# Altium schematic sheet AUPSC.SchDoc
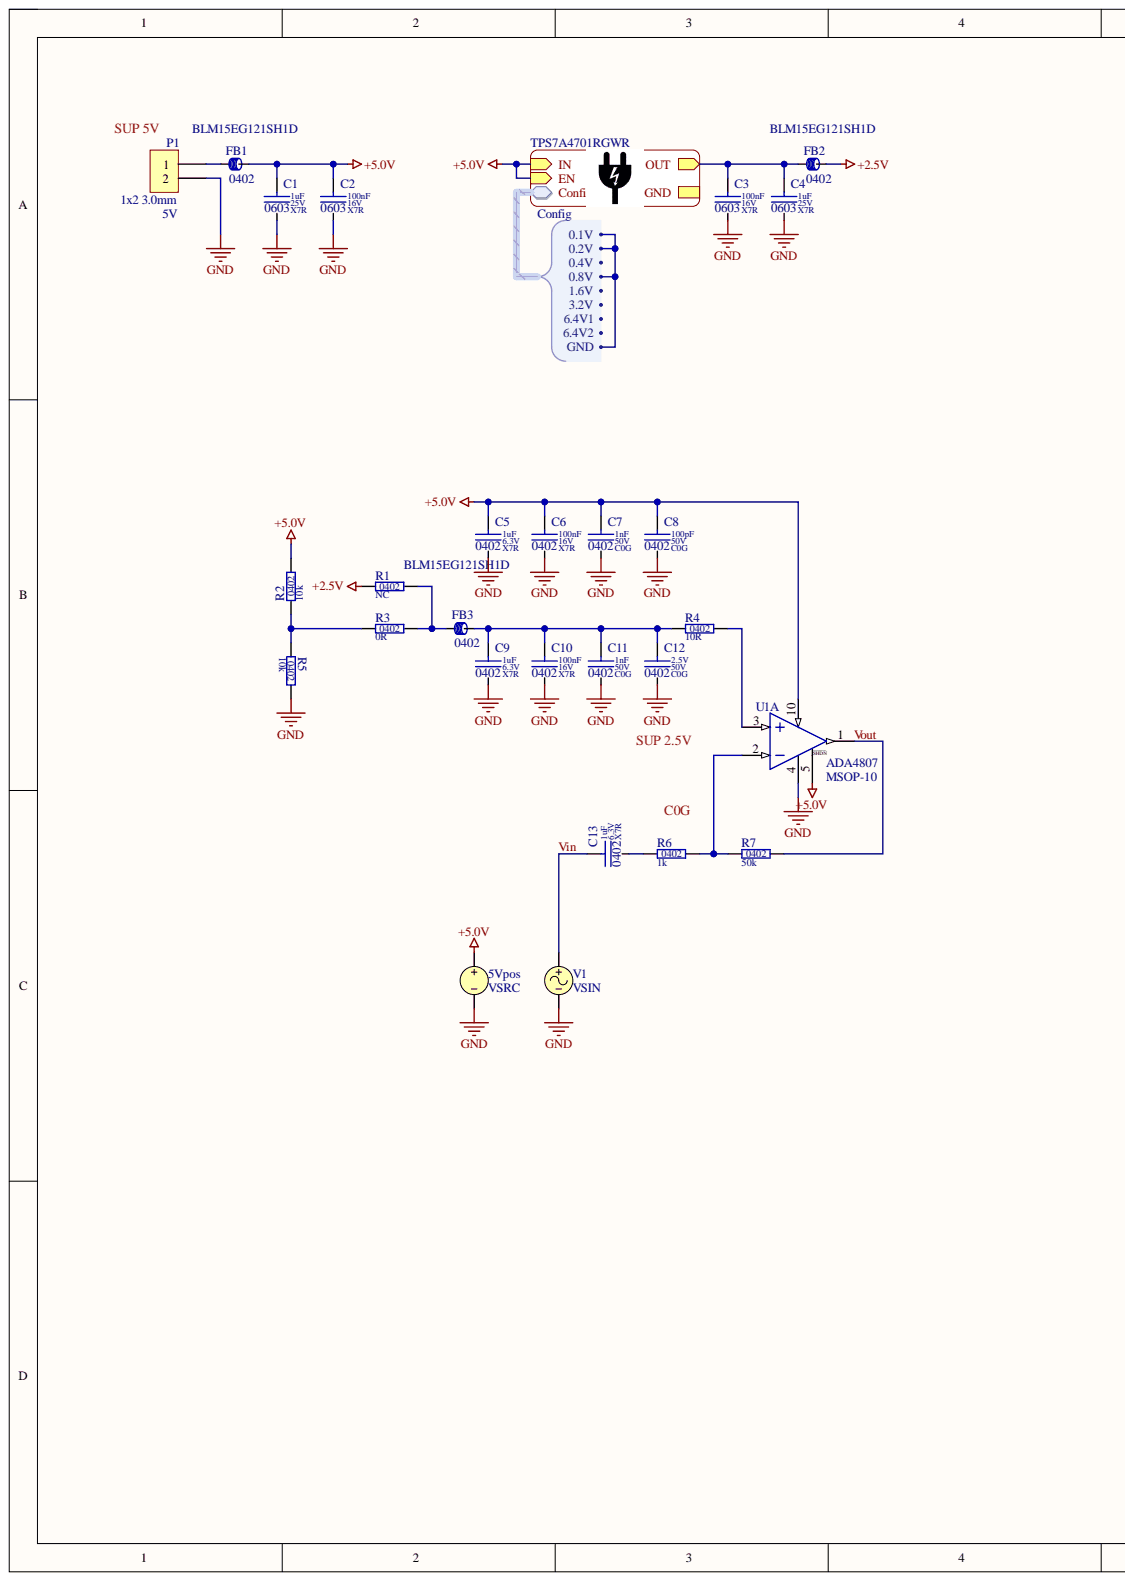
[diagram p0: AUPSC.SchDoc, left]
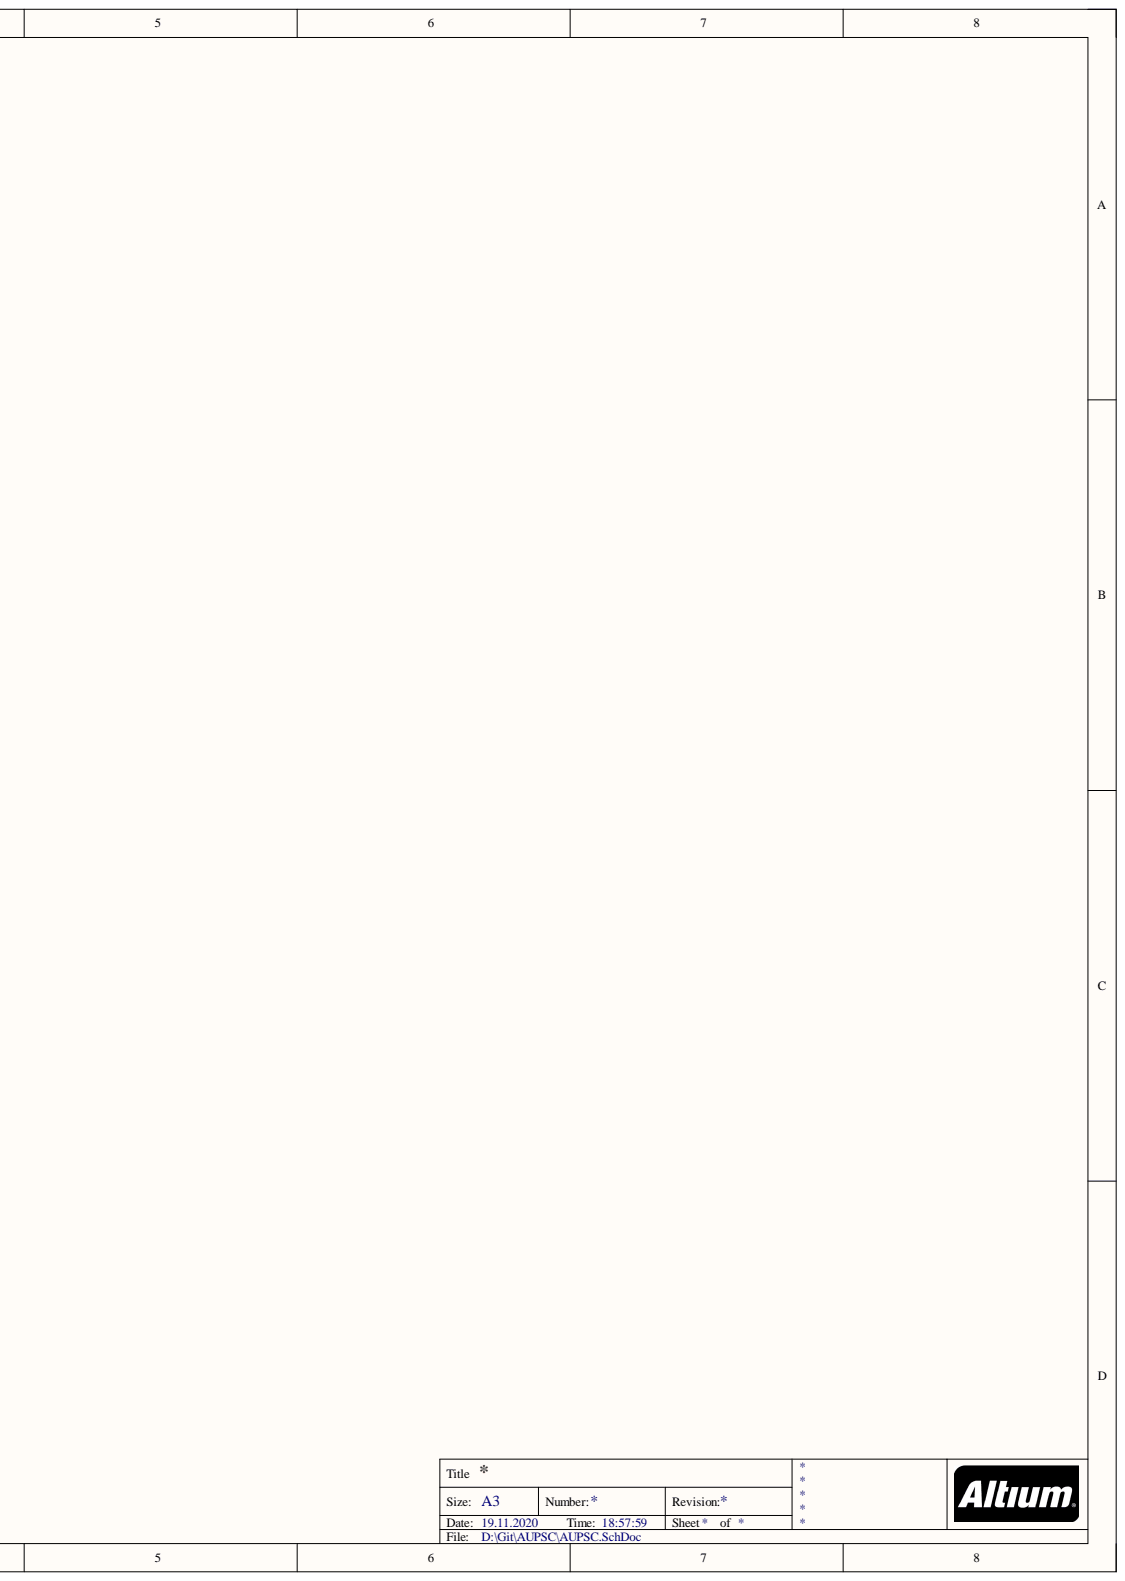
[diagram p1: AUPSC.SchDoc, right]

## Parts
5Vpos  VSRC
C1  value 1uF  Dielectric: X7R  SCH_Footprint: 0603  Voltage: 25V  [C_0603_1608]  (hidden comment: Ceramic X7R)
C2  value 100nF  Dielectric: X7R  SCH_Footprint: 0603  Voltage: 16V  [C_0603_1608]  (hidden comment: Ceramic X7R)
C3  value 100nF  Dielectric: X7R  SCH_Footprint: 0603  Voltage: 16V  [C_0603_1608]  (hidden comment: Ceramic X7R)
C4  value 1uF  Dielectric: X7R  SCH_Footprint: 0603  Voltage: 25V  [C_0603_1608]  (hidden comment: Ceramic X7R)
C5  value 1uF  Dielectric: X7R  SCH_Footprint: 0402  Voltage: 6.3V  [C_0402_1005]  (hidden comment: Ceramic X7R)
C6  value 100nF  Dielectric: X7R  SCH_Footprint: 0402  Voltage: 16V  [C_0402_1005]  (hidden comment: Ceramic X7R)
C7  value 1nF  Dielectric: C0G  SCH_Footprint: 0402  Voltage: 50V  [C_0402_1005]  (hidden comment: Ceramic C0G)
C8  value 100pF  Dielectric: C0G  SCH_Footprint: 0402  Voltage: 50V  [C_0402_1005]  (hidden comment: Ceramic C0G)
C9  value 1uF  Dielectric: X7R  SCH_Footprint: 0402  Voltage: 6.3V  [C_0402_1005]  (hidden comment: Ceramic X7R)
C10  value 100nF  Dielectric: X7R  SCH_Footprint: 0402  Voltage: 16V  [C_0402_1005]  (hidden comment: Ceramic X7R)
C11  value 1nF  Dielectric: C0G  SCH_Footprint: 0402  Voltage: 50V  [C_0402_1005]  (hidden comment: Ceramic C0G)
C12  value 2.5V  Dielectric: C0G  SCH_Footprint: 0402  Voltage: 50V  [C_0402_1005]  (hidden comment: Ceramic C0G)
C13  value 1uF  Dielectric: X7R  SCH_Footprint: 0402  Voltage: 6.3V  [C_0402_1005]  (hidden comment: Ceramic X7R)
FB1  value BLM15EG121SH1D  Manufacturer Part Number: BLM15EG121SH1D  SCH_Footprint: 0402  [FB_0402_1005]  (hidden comment: Ferrite bead)
FB2  value BLM15EG121SH1D  Manufacturer Part Number: BLM15EG121SH1D  SCH_Footprint: 0402  [FB_0402_1005]  (hidden comment: Ferrite bead)
FB3  value BLM15EG121SH1D  SCH_Footprint: 0402  [FB_0402_1005]  (hidden comment: Ferrite bead)
P1  value 5V  SCH_Footprint: 1x2 3.0mm  [P_MOLEX_MICROFIT_1x2_436500200]  (hidden comment: Molex Micro-Fit 2-pin)
R1  value NC  SCH_Footprint: 0402  [R_NC_0402_1005]  (hidden comment: Resistor)
R2  value 10k  SCH_Footprint: 0402  [R_0402_1005]  (hidden comment: Resistor)
R3  value 0R  SCH_Footprint: 0402  [R_0402_1005]  (hidden comment: Resistor)
R4  value 10R  SCH_Footprint: 0402  [R_0402_1005]  (hidden comment: Resistor)
R5  value 10k  SCH_Footprint: 0402  [R_0402_1005]  (hidden comment: Resistor)
R6  value 1k  SCH_Footprint: 0402  [R_0402_1005]  (hidden comment: Resistor)
R7  value 50k  SCH_Footprint: 0402  [R_NC_0402_1005]  (hidden comment: Resistor)
U1  value ADA4807  SCH_Footprint: MSOP-10  [MSOP10_3X3]  (hidden comment: AD8028ARMZ-REEL7)  (part A)
V1  VSIN

## Nets
+2.5V: FB2.2 R1.1
+5.0V: 5Vpos.1 C1.1 C2.1 C5.1 C6.1 C7.1 C8.1 FB1.2 R2.2 U1.5 U1.10
GND: 5Vpos.2 C1.2 C2.2 C3.2 C4.2 C5.2 C6.2 C7.2 C8.2 C9.2 C10.2 C11.2 C12.2 P1.2 R5.2 U1.4 V1.2
Vin: C13.1 V1.1
Vout: R7.2 U1.1
NetC3_1: C3.1 C4.1 FB2.1
NetC9_1: C9.1 C10.1 C11.1 C12.1 FB3.2 R4.1
NetC13_2: C13.2 R6.1
NetFB1_1: FB1.1 P1.1
NetFB3_1: FB3.1 R1.2 R3.2
NetR2_1: R2.1 R3.1 R5.1
NetR4_2: R4.2 U1.3
NetR6_2: R6.2 R7.1 U1.2
Config.0.1V:
Config.0.4V:
Config.1.6V:
Config.3.2V:
Config.6.4V1:
Config.6.4V2:

## Library data of the parts
5Vpos = VSRC: Voltage Source; Note: PCB Footprint - Not required; Value: 5V; Component Kind: Standard; LatestRevisionDate: 17-Jul-2002; LatestRevisionNote: Re-released for DXP Platform.; Library Name: Simulation Sources.IntLib; PackageReference: Not Applicable; Published: 8-Jun-2000; Simulation: VSRC; Publisher: Altium Limited
C1 C4 = C_1uF_25V_X7R_0603_10: Capacitor; Manufacturer: Samsung; Manufacturer Part Number: CL10B105KA8NNNC; Database Table Name: Capacitors; Tolerance (%): 10; Component Kind: Standard; HelpURL: http://www.tme.eu/pl/Document/4a42202b32dab16128fe107dd69598cc/samsung-chip-cap.pdf; Library Name: Library.DBLib; Part Number: C_1uF_25V_X7R_0603_10; Supplier 1: TME; Supplier 2: RS Components; Supplier 3: Farnell; Supplier 4: Mouser; Supplier 5: Digi-Key; Supplier 6: LCSC; Supplier Part Number 1: CL10B105KA8NNNC
C2 C3 = C_100nF_16V_X7R_0603_10: Capacitor; Manufacturer: Kemet; Manufacturer Part Number: C0603C104K4RAC7013; Database Table Name: Capacitors; Tolerance (%): 10; Component Kind: Standard; HelpURL: https://pl.mouser.com/datasheet/2/212/KEM_C1002_X7R_SMD-1102033.pdf; Library Name: Library.DBLib; Part Number: C_100nF_16V_X7R_0603_10; Supplier 1: TME; Supplier 2: RS Components; Supplier 3: Farnell; Supplier 4: Mouser; Supplier 5: Digi-Key; Supplier 6: LCSC; Supplier Part Number 4: 80-C0603C104K4RC7013; Supplier Part Number 5: 399-7372-2-ND
C5 C9 C13 = C_1uF_6.3V_X7R_0402_10: Capacitor; Manufacturer: Murata; Manufacturer Part Number: GRM155R70J105KA12D; Database Table Name: Capacitors; Tolerance (%): 10; Component Kind: Standard; HelpURL: https://www.mouser.com/ds/2/281/c02e-2905.pdf; Library Name: Library.DBLib; Part Number: C_1uF_6.3V_X7R_0402_10; Supplier 1: TME; Supplier 2: RS Components; Supplier 3: Farnell; Supplier 4: Mouser; Supplier 5: Digi-Key; Supplier Part Number 4: 81-GRM155R70J105KA2D
  C13: Simulation: Model Name; Supplier 6: LCSC
C6 C10 = C_100nF_16V_X7R_0402_10: Capacitor; Manufacturer: Murata; Manufacturer Part Number: GRM155R71C104KA88D; Database Table Name: Capacitors; Tolerance (%): 10; Component Kind: Standard; HelpURL: https://www.mouser.com/ds/2/281/c02e-2905.pdf; Library Name: Library.DBLib; Part Number: C_100nF_16V_X7R_0402_10; Supplier 1: TME; Supplier 2: RS Components; Supplier 3: Farnell; Supplier 4: Mouser; Supplier 5: Digi-Key; Supplier Part Number 4: 81-GRM155R71C104KA88
C7 C11 = C_1nF_50V_C0G_0402_5: Capacitor; Manufacturer: Murata; Manufacturer Part Number: GRM1555C1H102JA01D; Database Table Name: Capacitors; Tolerance (%): 5; Component Kind: Standard; HelpURL: http://www.tme.eu/en/Document/e3e731e59cc68b1ab6d46b158bb05733/MURATA_GRM.pdf; Library Name: Library.DBLib; Part Number: C_1nF_50V_C0G_0402_5; Supplier 1: TME; Supplier 2: RS Components; Supplier 3: Farnell; Supplier 4: Mouser; Supplier 5: Digi-Key; Supplier Part Number 1: GRM1555C1H102JA01D; Supplier Part Number 2: 6242913; Supplier Part Number 3: 2408520; Supplier Part Number 4: 81-GRM1555C1H102JA1D; Supplier Part Number 5: 490-3244-1-ND
C8 C12 = C_100pF_50V_C0G_0402_5: Capacitor; Manufacturer: Murata; Manufacturer Part Number: GRM1555C1H101JA01D; Database Table Name: Capacitors; Tolerance (%): 5; Component Kind: Standard; HelpURL: http://www.tme.eu/en/Document/e3e731e59cc68b1ab6d46b158bb05733/MURATA_GRM.pdf; Library Name: Library.DBLib; Part Number: C_100pF_50V_C0G_0402_5; Supplier 1: TME; Supplier 2: RS Components; Supplier 3: Farnell; Supplier 4: Mouser; Supplier 5: Digi-Key; Supplier Part Number 1: GRM1555C1H101JA01D; Supplier Part Number 2: 7235363; Supplier Part Number 3: 2218836; Supplier Part Number 4: 81-GRM1555C1H101JA1D; Supplier Part Number 5: 490-5922-1-ND
  C12: Simulation: Model Name
FB1-FB3 = FB_BLM15EG121SH1D: Manufacturer: Murata; Current: 1.5A; Database Table Name: Inductors; Resistance: 95mR; Component Kind: Standard; Description: Ferrite Bead; HelpURL: http://datasheet.octopart.com/BLM15EG121SH1D-Murata-datasheet-37129980.pdf; Library Name: Library.DBLib; Part Number: FB_BLM15EG121SH1D; Supplier 1: TME; Supplier 2: RS Components; Supplier 3: Farnell; Supplier 4: Mouser; Supplier 5: Digi-Key; Supplier 6: LCSC; Supplier Part Number 3: 2871614; Supplier Part Number 4: 81-BLM15EG121SH1D; Supplier Part Number 5: 490-17344-1-ND
  FB3: Manufacturer Part Number: BLM15EG121SH1D; Value: BLM15EG121SH1D
P1 = P_MOLEX_MICROFIT_1x2_436500200: Pin Header; Manufacturer Part Number: 43650-0200; Database Table Name: Connectors; Component Kind: Standard; HelpURL: https://www.molex.com/molex/products/part-detail/pcb_headers/0436500200; Library Name: Library.DBLib; Manufacturer: Molex; Part Number: P_MOLEX_MICROFIT_1x2_436500200; Simulation: Model Name; Supplier 1: TME; Supplier 2: RS Components; Supplier 3: Farnell; Supplier 4: Mouser; Supplier 5: Digi-Key; Supplier 6: LCSC; Supplier Part Number 1: MX-43650-0200; Supplier Part Number 2: 6704698; Supplier Part Number 3: 2324861; Supplier Part Number 4: 538-43650-0200; Supplier Part Number 5: WM1860-ND
R1 R7 = R_GENERIC_0402: Database Table Name: Resistors; Component Kind: Standard; Library Name: Library.DBLib; Part Number: R_GENERIC_0402; Supplier 1: TME; Supplier 2: RS Components; Supplier 3: Farnell; Supplier 4: Mouser; Supplier 5: Digi-Key; Supplier 6: LCSC
  R7: Simulation: Model Name
R2 R5 = R_10k_0402_1: Manufacturer: Yageo; Manufacturer Part Number: RC0402FR-0710KL; Database Table Name: Resistors; Power: 63mW; Tolerance: 1%; Voltage: 50V; Component Kind: Standard; HelpURL: http://www.tme.eu/pl/Document/1a2bc76e2be68b3b1670068306778ce6/rc0402yageo.pdf; Library Name: Library.DBLib; Part Number: R_10k_0402_1; Supplier 1: TME; Supplier 2: RS Components; Supplier 3: Farnell; Supplier 4: Mouser; Supplier 5: Digi-Key; Supplier 6: LCSC; Supplier Part Number 1: RC0402FR-0710K
R3 = R_0R_0402_5: Manufacturer: Yageo; Manufacturer Part Number: RC0402JR-070RL; Database Table Name: Resistors; Power: 63mW; Tolerance: 5%; Voltage: 50V; Component Kind: Standard; HelpURL: https://datasheet.octopart.com/RC0402JR-070RL-Yageo-datasheet-10408619.pdf; Library Name: Library.DBLib; Part Number: R_0R_0402_5; Supplier 1: TME; Supplier 2: RS Components; Supplier 3: Farnell; Supplier 4: Mouser; Supplier 5: Digi-Key; Supplier 6: LCSC; Supplier Part Number 1: RC0402JR-070R; Supplier Part Number 2: 6185804; Supplier Part Number 3: 9232516; Supplier Part Number 4: 603-RC0402JR-070RL; Supplier Part Number 5: 311-0.0JRCT-ND; Supplier Part Number 6: C60485
R4 = R_10R_0402_1: Manufacturer: Yageo; Manufacturer Part Number: RC0402FR-0710R; Database Table Name: Resistors; Power: 63mW; Tolerance: 1%; Voltage: 50V; Component Kind: Standard; HelpURL: http://www.tme.eu/pl/Document/1a2bc76e2be68b3b1670068306778ce6/rc0402yageo.pdf; Library Name: Library.DBLib; Part Number: R_10R_0402_1; Simulation: Model Name; Supplier 1: TME; Supplier 2: RS Components; Supplier 3: Farnell; Supplier 4: Mouser; Supplier 5: Digi-Key; Supplier 6: LCSC; Supplier Part Number 1: RC0402FR-0710R; Supplier Part Number 2: 6185848; Supplier Part Number 3: 2128710; Supplier Part Number 4: 603-RC0402FR-0710RL; Supplier Part Number 5: 311-10.0LRCT-ND
R6 = R_1k_0402_1: Manufacturer: Yageo; Manufacturer Part Number: RC0402FR-071K; Database Table Name: Resistors; Power: 63mW; Tolerance: 1%; Voltage: 50V; Component Kind: Standard; HelpURL: http://www.tme.eu/pl/Document/1a2bc76e2be68b3b1670068306778ce6/rc0402yageo.pdf; Library Name: Library.DBLib; Part Number: R_1k_0402_1; Simulation: Model Name; Supplier 1: TME; Supplier 2: RS Components; Supplier 3: Farnell; Supplier 4: Mouser; Supplier 5: Digi-Key; Supplier 6: LCSC; Supplier Part Number 1: RC0402FR-071K; Supplier Part Number 3: 9239235; Supplier Part Number 4: 603-RC0402FR-071KP; Supplier Part Number 5: 311-1.00KLRCT-ND
U1 = U_AD8028ARMZ-REEL7: Manufacturer: Analog Devices; Database Table Name: OpAmps; Component Kind: Standard; HelpURL: https://datasheet.octopart.com/AD8028ARMZ-REEL7-Analog-Devices-datasheet-10993.pdf; Library Name: Library.DBLib; Manufacturer Part Number: AD8028ARMZ-REEL7; Part Number: U_AD8028ARMZ-REEL7; Simulation: ADA4807; Supplier 1: TME; Supplier 2: RS Components; Supplier 3: Farnell; Supplier 4: Mouser; Supplier 5: Digi-Key; Supplier 6: LCSC; Supplier Part Number 4: 584-AD8028ARMZ-R7; Supplier Part Number 5: AD8028ARMZ-REEL7CT-ND
V1 = VSIN: Sinusoidal Voltage Source; Note: PCB Footprint - Not required; Component Kind: Standard; LatestRevisionDate: 15-Nov-2004; LatestRevisionNote: Corrected Netlist.; Library Name: Simulation Sources.IntLib; PackageReference: Not Applicable; Published: 8-Jun-2000; Simulation: VSIN; Publisher: Altium Limited
